# Altium schematic sheet Schematic.SchDoc
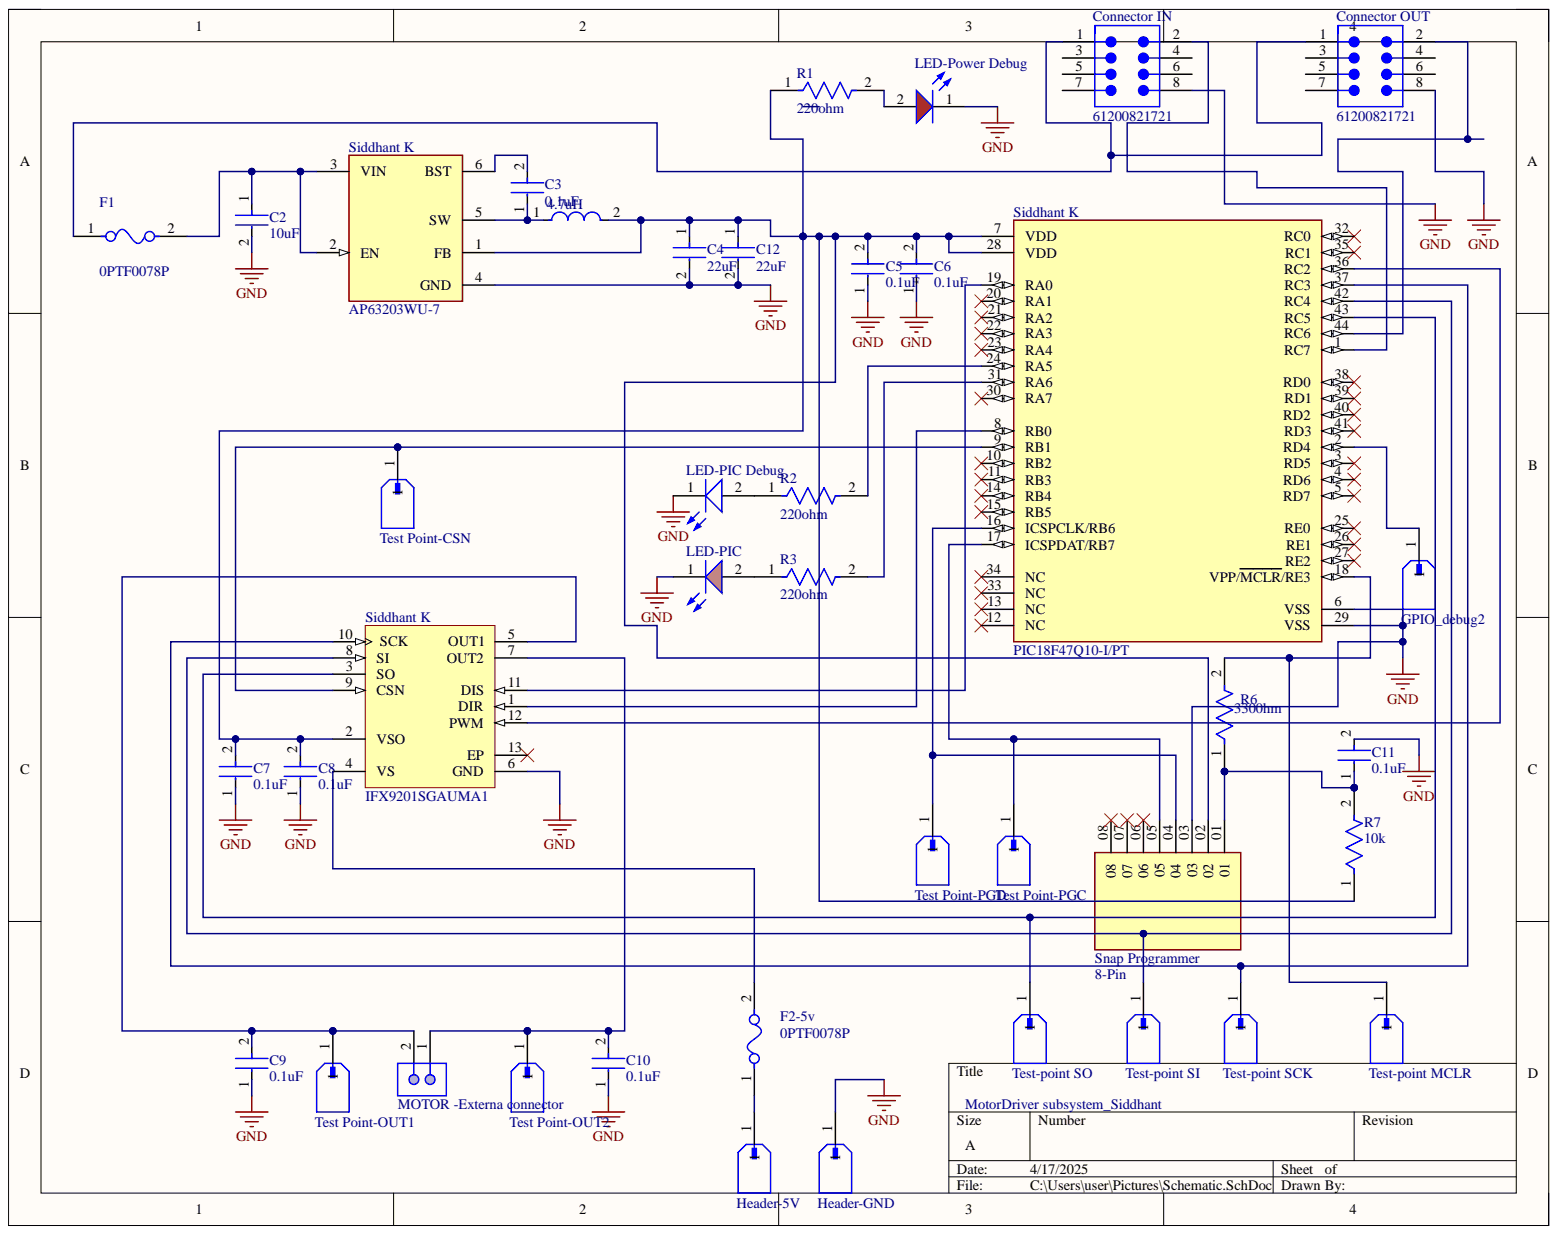
[diagram p0: Schematic.SchDoc]

## Parts
4.7uH  (no value)  [FP-LQM21PH_G0-IPC_C]
C2  10uF  [FP-GCJ31C-0_3-MFG]
C3  0.1uF  [FP-GCJ188-0_2-IPC_C]
C4  22uF  [FP-GRT188-0_2-IPC_A]
C5  0.1uF  [FP-GCJ188-0_2-IPC_C]
C6  0.1uF  [FP-GCJ188-0_2-IPC_C]
C7  0.1uF  [FP-GCJ188-0_2-IPC_C]
C8  0.1uF  [FP-GCJ188-0_2-IPC_C]
C9  0.1uF  [FP-GCJ188-0_2-IPC_C]
C10  0.1uF  [FP-GCJ188-0_2-IPC_C]
C11  0.1uF  [FP-GCJ188-0_2-IPC_C]
C12  22uF  [FP-GRT188-0_2-IPC_A]
Connector_IN  61200821721  [61200821721]
Connector_OUT  61200821721  [61200821721]
F1  0PTF0078P  [FP-0PTF0078P-MFG]
F2-5v  0PTF0078P  [FP-0PTF0078P-MFG]
GPIO_debug2  (no value)  [ADAM-TECH_PH1-01-UA]
Header-5V  (no value)  [ADAM-TECH_PH1-01-UA]
Header-GND  (no value)  [ADAM-TECH_PH1-01-UA]
LED-PIC  (no value)  [WL-SMCW_0603_150060xx75000]
LED-PIC_Debug  (no value)  [WL-SWTP_3014_L3W1.4H0.65]
LED-Power_Debug  (no value)  [WL-SMCW_0603_150060xx75020]
MOTOR_-Externa_connector  (no value)  [MOLX-22-23-2021_V]
R1  220ohm  [FP-CRCW0402-Ce3-MFG]
R2  220ohm  [FP-CRCW0402-Ce3-MFG]
R3  220ohm  [FP-CRCW0402-Ce3-MFG]
R6  3300hm  [FP-CRCW0603-e3-MFG]
R7  10k  [FP-CRCW0402-e3-IPC_B]
Siddhant_K  PIC18F47Q10-I/PT  [FP-C04-076-IPC_A]
Siddhant_K  IFX9201SGAUMA1  [INF-PG-DSO-12-17_L]
Siddhant_K  AP63203WU-7  [FP-TSOT26-MFG]
Snap_Programmer  8-Pin  [SAMTEC_MTSW-108-08-G-S-335]
Test_Point-CSN  (no value)  [ADAM-TECH_PH1-01-UA]
Test_Point-OUT1  (no value)  [ADAM-TECH_PH1-01-UA]
Test_Point-OUT2  (no value)  [ADAM-TECH_PH1-01-UA]
Test_Point-PGC  (no value)  [ADAM-TECH_PH1-01-UA]
Test_Point-PGD  (no value)  [ADAM-TECH_PH1-01-UA]
Test-point_MCLR  (no value)  [ADAM-TECH_PH1-01-UA]
Test-point_SCK  (no value)  [ADAM-TECH_PH1-01-UA]
Test-point_SI  (no value)  [ADAM-TECH_PH1-01-UA]
Test-point_SO  (no value)  [ADAM-TECH_PH1-01-UA]

## Nets
GND: C2.2 C4.2 C5.1 C6.1 C7.1 C8.1 C9.1 C10.1 C11.2 C12.2 Connector_IN.8 Connector_OUT.8 Header-GND.1 LED-PIC.1 LED-PIC_Debug.1 LED-Power_Debug.1 Siddhant_K.4 Siddhant_K.6 Siddhant_K.29(VSS) Snap_Programmer.03
Net4.7uH_1: 4.7uH.1 C3.1 Siddhant_K.5
Net4.7uH_2: 4.7uH.2 C4.1 C5.2 C6.2 C7.2 C8.2 C12.1 R1.1 R7.1 Siddhant_K.1 Siddhant_K.2 Siddhant_K.7 Siddhant_K.28(VDD) Snap_Programmer.02
NetC2_1: C2.1 F1.2 Siddhant_K.2 Siddhant_K.3
NetC3_2: C3.2 Siddhant_K.6
NetC9_2: C9.2 MOTOR_-Externa_connector.2 Siddhant_K.5 Test_Point-OUT1.1
NetC10_2: C10.2 MOTOR_-Externa_connector.1 Siddhant_K.7 Test_Point-OUT2.1
NetC11_1: C11.1 R6.1 R7.2 Snap_Programmer.01
NetConnector IN_1: Connector_IN.1 Connector_OUT.1 F1.1
NetConnector IN_2: Connector_IN.2 Siddhant_K.1
NetConnector OUT_2: Connector_OUT.2 Siddhant_K.44(RC6)
NetF2-5v_1: F2-5v.1 Header-5V.1
NetF2-5v_2: F2-5v.2 Siddhant_K.4
NetGPIO_debug2_1: GPIO_debug2.1 Siddhant_K.2
NetLED-PIC Debug_2: LED-PIC_Debug.2 R2.1
NetLED-PIC_2: LED-PIC.2 R3.1
NetLED-Power Debug_2: LED-Power_Debug.2 R1.2
NetR2_2: R2.2 Siddhant_K.24(RA5)
NetR3_2: R3.2 Siddhant_K.31(RA6)
NetR6_2: R6.2 Siddhant_K.18(VPP/MCLR/RE3) Test-point_MCLR.1
NetSiddhant K_1: Siddhant_K.1 Siddhant_K.8
NetSiddhant K_3: Siddhant_K.3 Siddhant_K.43(RC5) Test-point_SO.1
NetSiddhant K_8: Siddhant_K.8 Siddhant_K.42(RC4) Test-point_SI.1
NetSiddhant K_9: Siddhant_K.9 Test_Point-CSN.1
NetSiddhant K_10: Siddhant_K.10 Siddhant_K.37(RC3) Test-point_SCK.1
NetSiddhant K_11: Siddhant_K.11 Siddhant_K.19(RA0)
NetSiddhant K_12: Siddhant_K.12 Siddhant_K.36(RC2)
NetSiddhant K_16: Siddhant_K.16(ICSPCLK/RB6) Snap_Programmer.04 Test_Point-PGD.1
NetSiddhant K_17: Siddhant_K.17(ICSPDAT/RB7) Snap_Programmer.05 Test_Point-PGC.1

Not connected: Connector_IN 3 4 5 6 7; Connector_OUT 3 4 5 6 7; Siddhant_K 13 14(RB4) 15(RB5) 20(RA1) 21(RA2) 22(RA3) 23(RA4) 25(RE0) 26(RE1) 27(RE2) 30(RA7) 32(RC0) 33(NC) 34(NC) 35(RC1) 38(RD0) 39(RD1) 40(RD2) 41(RD3); Snap_Programmer 06 07 08

## Library data of the parts
4.7uH = CMP-06042-017011-1: Multilayer Type Inductor for Power Lines for Automotive, 0805 (2012), 4.7μH, 30%, 0.288Ω, 0.8A; Component Kind: Standard; Library Name: Altium Content Vault; RoHS Compliant: Yes
C2 = CMP-06035-000150-1: Cap Ceramic 10uF 16V X7R 10% Pad SMD 1206 Soft Termination  +125°C Automotive T/R; Capacitance: 10uF; Case Code (Imperial): 1206; Case Code (Metric): 3216; Case/Package: 1206; Component Kind: Standard; Dielectric: X7R; Features: Soft Termination; Height: 1.25mm; Length: 0.126inch; Library Name: Altium Content Vault; Max Operating Temperature: 125°C; Min Operating Temperature: -55°C; Mount: Surface Mount; Package Quantity: 2000; Packaging: Tape and Reel; Ratings: AEC-Q200; Termination: SMD/SMT; Thickness: 0.075inch; Tolerance: 10%; Voltage Rating: 16V; Voltage Rating (DC): 16V; Weight: 0.001411oz; Width: 1.6mm
C3 C5-C11 = CMP-06035-054388-1: Cap Ceramic 0.1uF 50V X8R 10% Pad SMD 0603 Soft Termination +150°C Automotive T/R; Component Kind: Standard; Library Name: Altium Content Vault
C4 C12 = CMP-06035-057738-1: Multi-Layer Ceramic Capacitor 22uF 6.3V X5R ±20% 0603 Paper T/R; Component Kind: Standard; Library Name: Altium Content Vault
Connector_IN Connector_OUT = CMP-1502-00020-2: NexarPartId: 32855461; Connector Type: Male Pin; Contact Resistance: 20mΩ; Current Rating: 3A; Gender: Male; Insulation Resistance: 1GΩ; Number of Contacts: 8; Operating Supply Voltage: 250V; Pitch: 2.54mm; Color: Black; Component Kind: Standard; Contact Material: Copper; Contact Plating: Gold; Insulation Material: PBT; Lead Pitch: 2.54mm; Length: 17.82mm; Library Name: Altium Content Vault; Max Operating Temperature: 105°C; Min Operating Temperature: -40°C; Mount: Through Hole; Number of Pins: 8; Number of Positions: 8; Number of Rows: 2; REACH SVHC: Unknown; RoHS: Compliant; Row Spacing: 2.54mm; Termination: Solder
F1 F2-5v = CMP-08604-000023-1: FUSE BLOCK CARTRIDGE PCB; Manufacturer: Littelfuse Inc; Conn Orientation: Vertical; Fuse Current: 6.3A; Number Of Fuses: 1; Voltage Rating Ac: 250V; Ambient Temperature Range High: +85°C; Ambient Temperature Range Low: -30°C; Automotive: No; Category: Fuse; Component Kind: Standard; ComponentLink2Description: Datasheet; ComponentLink2URL: https://media.digikey.com/pdf/Data%20Sheets/Littelfuse%20PDFs/PTF075_77_78.pdf; Device Class L1: Circuit Protection; Device Class L2: Fuse Holders; Device Class L3: unset; Digikey Description: FUSE BLOCK CARTRIDGE PCB; Height: 11.5mm; Lead Free: Yes; Library Name: Altium Content Vault; Manufacturer Part Number: 0PTF0078P; Mouser Description: Fuse Holder 5X20MM Pitch 22/7 UL94V0 Open; Octopart: https://octopart.com/0ptf0078p-littelfuse-13255855; Package: 0; RoHS: TRUE; Voltage Rating Dc: 250V
GPIO_debug2 Header-5V Header-GND Test-point_MCLR Test-point_SCK Test-point_SI Test-point_SO Test_Point-CSN Test_Point-OUT1 Test_Point-OUT2 Test_Point-PGC Test_Point-PGD = PH1-01-UA: Connector Header Through Hole 1 position 0.100 (2.54mm); MF: Adam Tech; Availability: Warning; Check_prices: https://www.snapeda.com/parts/PH1-01-UA/Adam%20Tech/view-part/4254992/?ref=eda; Component Kind: Standard; Library Name: PH1-01-UA.IntLib; MP: PH1-01-UA; Package: None; Price: None; Purchase-URL: https://pricing.snapeda.com/search/part/PH1-01-UA/?ref=eda; SnapEDA_Link: https://www.snapeda.com/parts/PH1-01-UA/Adam%20Tech/view-part/4254992/?ref=snap
LED-PIC = CMP-03913-89970694-2: WL-SMCW SMT Mono-Color Chip LED Waterclear; Dominant Wavelength: 605nm; Forward Current: 100mA; Forward Voltage: 2V; Luminous Intensity: 130mcd; Max Reverse Voltage (DC): 5V; Peak Wavelength: 610nm; Viewing Angle: 140°; Component Kind: Standard; Library Name: Altium Content Vault; Max Operating Temperature: 85°C; Min Operating Temperature: -40°C; Packaging: Tape and Reel; RoHS: Compliant; Wavelength: 605nm
LED-PIC_Debug = CMP-03913-108242173-1: 158301260A Led, Hb, Cool White, 6000K, 26Lm Rohs Compliant: Yes; Forward Current: 200mA; Forward Voltage: 2.8V; Luminous Flux: 20lm; Viewing Angle: 120°; Component Kind: Standard; Height: 650µm; Length: 3mm; Library Name: Altium Content Vault; Max Operating Temperature: 85°C; Min Operating Temperature: -40°C; NexarPartId: 108242173; RoHS: Compliant; Width: 1.4mm
LED-Power_Debug = CMP-03913-104891427-2: WL-SMCW SMT Mono-Color Chip LED Waterclear super f; Dominant Wavelength: 630nm; Forward Current: 60mA; Forward Voltage: 1.9V; Luminous Intensity: 70mcd; Max Reverse Voltage (DC): 5V; Peak Wavelength: 645nm; Viewing Angle: 140°; Component Kind: Standard; Height: 250µm; Length: 1.6mm; Library Name: Altium Content Vault; Max Operating Temperature: 85°C; Min Operating Temperature: -40°C; RoHS: Compliant; Width: 800µm
MOTOR_-Externa_connector = CMP-1704-00001-3: Male Header, Pitch 2.54 mm, 1 x 2 Position, Height 10.67 mm, Tail Length 3.56 mm, 0 to 75 degC, RoHS, Bulk; Component Kind: Standard; ComponentLink1Description: Manufacturer URL; ComponentLink1URL: http://www.molex.com/; ComponentLink2Description: Datasheet; ComponentLink2URL: https://datasheet.ciiva.com/19454/232266-19454304.pdf; Height: 10.67 mm; Length: 5.08 mm; Library Name: Altium Content Vault; Max Operating Temperature: 75 degC; Min Operating Temperature: 0 degC; Mount: Through Hole; Mounting Technology: Through Hole; Package Quantity: 3000; PackageDescription: Male Header, Pitch 2.54 mm, 1 x 2 Position, Height 10.67 mm, Tail Length 3.56 mm; Packaging: Bulk; PartNumber: 22-23-2021; Pins: 2; Radiation Hardening: No; REACH SVHC: Unknown; RoHSCompliant: True; Width: 6.35 mm
R1-R3 = CMP-00030-87882688-1: CRCW0402220RFKEDC SMD Chip Resistor, 220 Ohm, ± 1%, 63 mW, 0402 [1005 Metric], Thick Film, General Purpose; Resistance: 220Ω; Case/Package: 0402; Component Kind: Standard; Lead Free: Lead Free; Library Name: Altium Content Vault; NexarPartId: 87882688; RoHS: Compliant; Schedule B: 8533210045
R6 = CMP-1012-00454-3: RES Thick Film, 330Ω, 1%, 0.1W, 100ppm/°C, 0603; Max Power Dissipation: 100mW; Resistance: 330R; Voltage Rating: 75V; Case Code (Imperial): 0603; Case Code (Metric): 1608; Case/Package: 0603; Component Kind: Standard; Composition: Thick Film; Contact Plating: Tin; Depth: 0.85mm; Features: Automotive AEC-Q200; Height: 0.45mm; Lead Free: Lead Free; Length: 1.55mm; Library Name: Altium Content Vault; Max Operating Temperature: 155°C; Military Standard: Not; Min Operating Temperature: -55°C; Mount: Surface Mount; Number of Terminations: 2; Package Quantity: 10; Packaging: Tape and Reel; Power Rating: 100mW; Radiation Hardening: No; Temperature Coefficient: 100ppm/°C; Termination: SMD/SMT; Tolerance: 1%; Voltage Rating (DC): 75V; Weight: 7.1E-05oz; Width: 0.033inch
R7 = CMP-2002-08135-2: RES SMD 10K OHM 1% 1/16W 0402; Max Power Dissipation: 63mW; Resistance: 10kR; Temperature Coefficient: 100ppm/°C; Voltage Rating: 50V; Case Code (Imperial): 0402; Case Code (Metric): 1005; Case/Package: 0402; Component Kind: Standard; Composition: Thick Film; Contact Plating: Tin; Depth: 0.5mm; Features: Automotive AEC-Q200; Height: 0.35mm; Lead Free: Lead Free; Length: 1mm; Library Name: Altium Content Vault; Max Operating Temperature: 155°C; Military Standard: Not; Min Operating Temperature: -55°C; Mount: Surface Mount; Number of Pins: 2; Number of Terminations: 2; Package Quantity: 10; Packaging: Tape and Reel; Power Rating: 63mW; Radiation Hardening: No; Termination: SMD/SMT; Tolerance: 1%; Voltage Rating (DC): 50V; Weight: 2.3E-05oz; Width: 0.02inch
Siddhant_K = CMP-06276-000299-1: IC MCU 8BIT 128KB FLASH 44TQFP; Component Kind: Standard; Library Name: Altium Content Vault; RoHS Compliant: Yes
Siddhant_K = CMP-1645-00001-1: 6 A H-Bridge with SPI, 0.1 Ohm, -40 to 125 degC, 12-Pin PG-DSO-12-17, RoHS, Tape and Reel; RDS(on): 0.1 Ohm; Component Kind: Standard; ComponentLink1Description: Manufacturer URL; ComponentLink1URL: http://www.infineon.com/; ComponentLink2Description: Datasheet; ComponentLink2URL: http://www.infineon.com/dgdl/Infineon-IFX9201SG-DS-v01_01-EN.pdf?fileId=5546d4624cb7f111014d2e8916795dea; ComponentLink3Description: Package Specification; ComponentLink3URL: http://www.infineon.com/dgdl/TLE9201SG_DS_10.pdf?folderId=db3a30431b3e89eb011bb632994f065b&fileId=db3a304345087709014518190f481cec&ack=t; DatasheetVersion: Rev. 1.1; Generic Part Number: IFX9201SG; Library Name: Altium Content Vault; Mounting Technology: Surface Mount; PackageDescription: 12-Pin Plastic (Green) Dual Small Outline Package, 7.5 x 6.4 mm Body, 1 mm Pitch; Packing: Tape and Reel; PartNumber: IFX9201SGAUMA1; RoHS: TRUE; Temperature Max: 125 degC; Temperature Min: -40 degC
Siddhant_K = CMP-12757-000006-1: IC REG BUCK 3.3V 2A TSOT26; Manufacturer: Diodes Inc.; Max Junction Temp: +160°C; Max Supply Voltage: 32V; Min Supply Voltage: 3.8V; Nominal Supply Current: 22uA; Number Of Outputs: 1; Output Type: Fixed; Standoff Height: 0.01mm; Switching Frequency: 1.1MHz; Ambient Temperature Range High: +85°C; Ambient Temperature Range Low: -40°C; Automotive: No; Category: IC; Component Kind: Standard; ComponentLink2Description: Datasheet; ComponentLink2URL: https://www.diodes.com/assets/Datasheets/AP63200-AP63201-AP63203-AP63205.pdf; Device Class L1: Integrated Circuits (ICs); Device Class L2: Power Management ICs; Device Class L3: Voltage Regulators - Switching; Digikey Description: IC REG BUCK 3.3V 2A TSOT26; Footprint Url: https://www.diodes.com/assets/Package-Files/TSOT26.pdf; Height: 1mm; Lead Free: Yes; Library Name: Altium Content Vault; Manufacturer Part Number: AP63203WU-7; Mouser Description: AP63203 Series 3.3 V 2 A SMT Fixed Low IQ Synchronous Buck - TSOT-26; Octopart: https://octopart.com/ap63203wu-7-diodes+inc.-98099550; Output Current: 2A; Output Voltage: 3.3V; Package: TSOT26; RoHS: TRUE; Switching Topology: Buck; Temperature: to +160°C; Temperature Range High: +160°C
Snap_Programmer = MTSW-108-08-G-S-335: Availability: Unavailable; Component Kind: Standard; Library Name: MTSW-108-08-G-S-335.IntLib; MP: MTSW-108-08-G-S-335; Package: None; Price: None
